# Altium schematic sheet Frost5000Beta_Sch.SchDoc(Frost5000Beta_Sch)
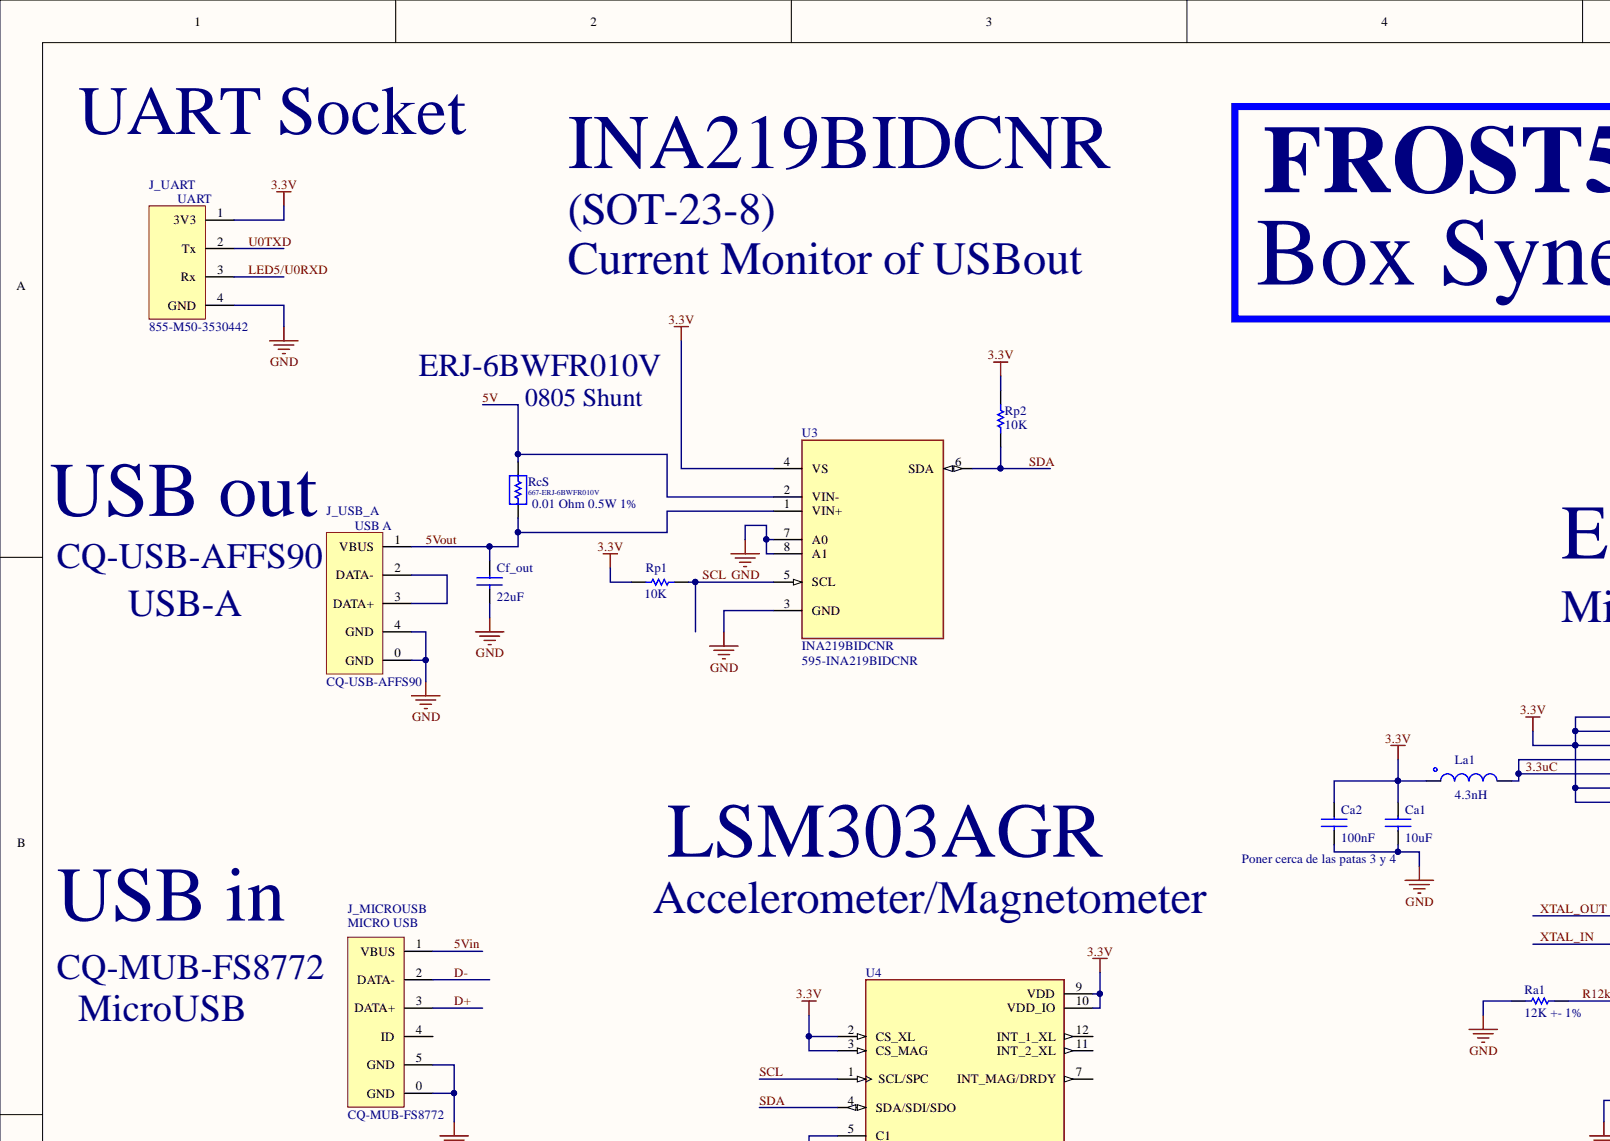
[diagram p0: Frost5000Beta_Sch.SchDoc, top left]
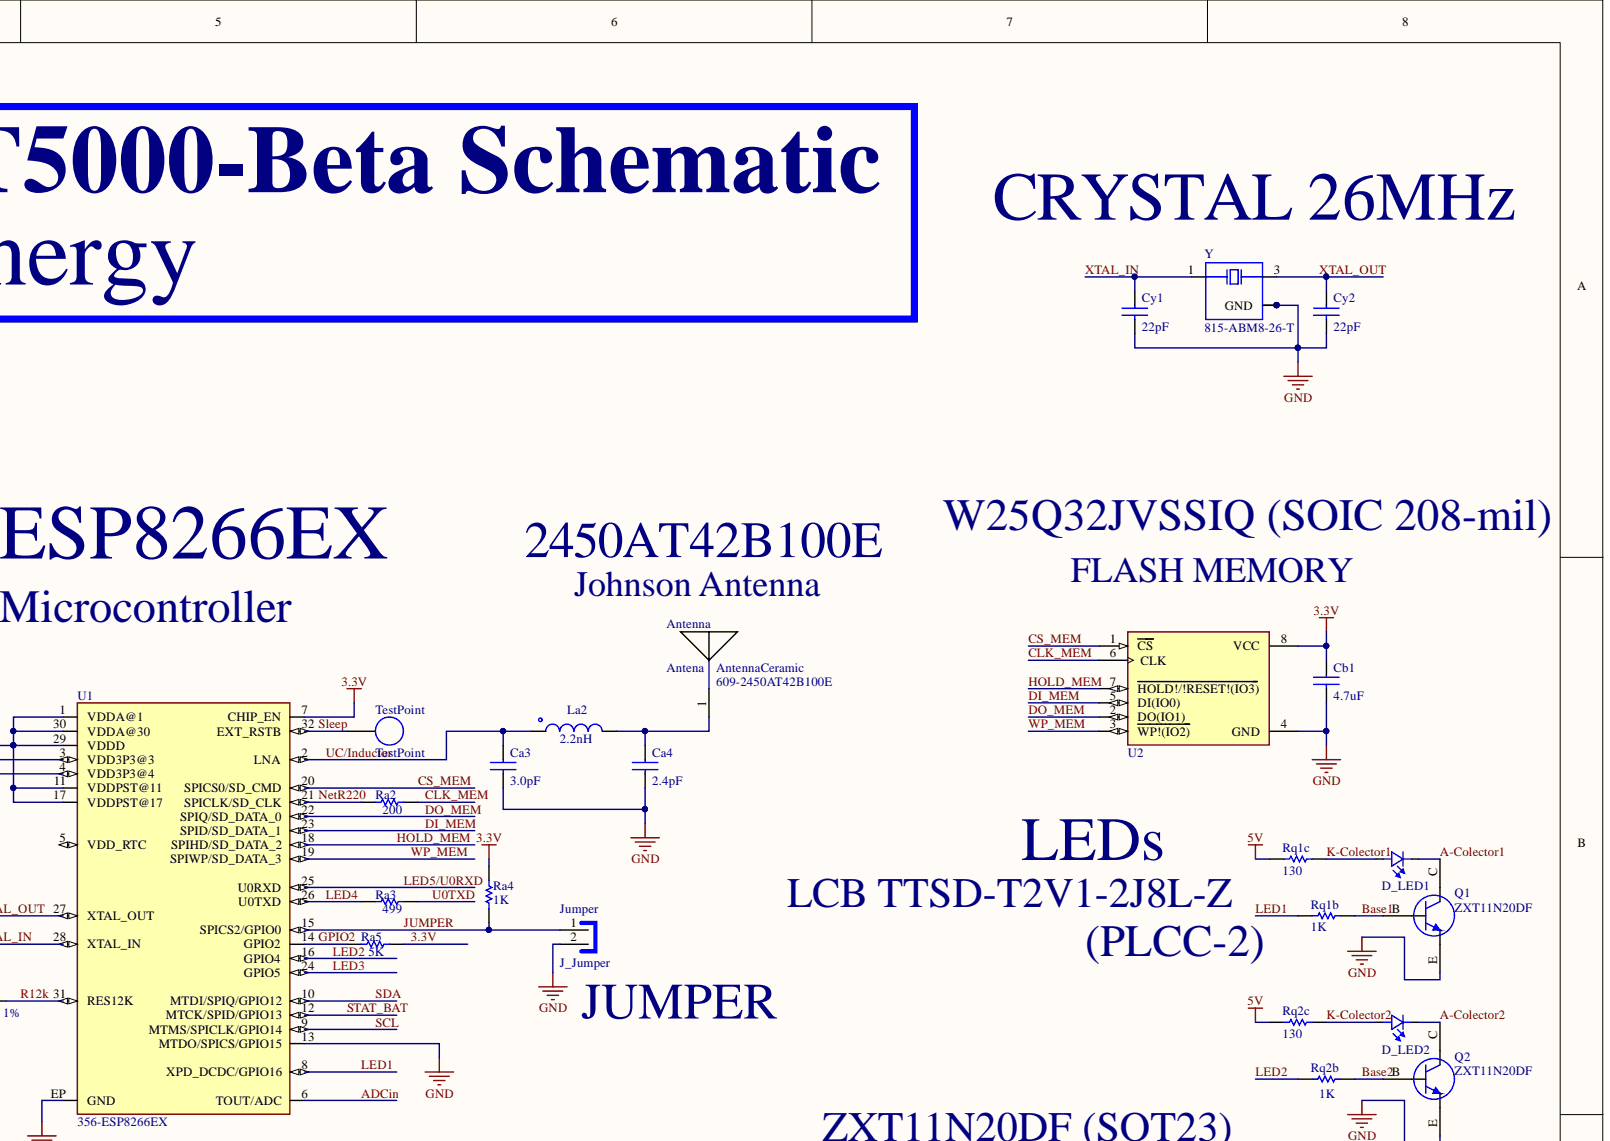
[diagram p1: Frost5000Beta_Sch.SchDoc, top right]
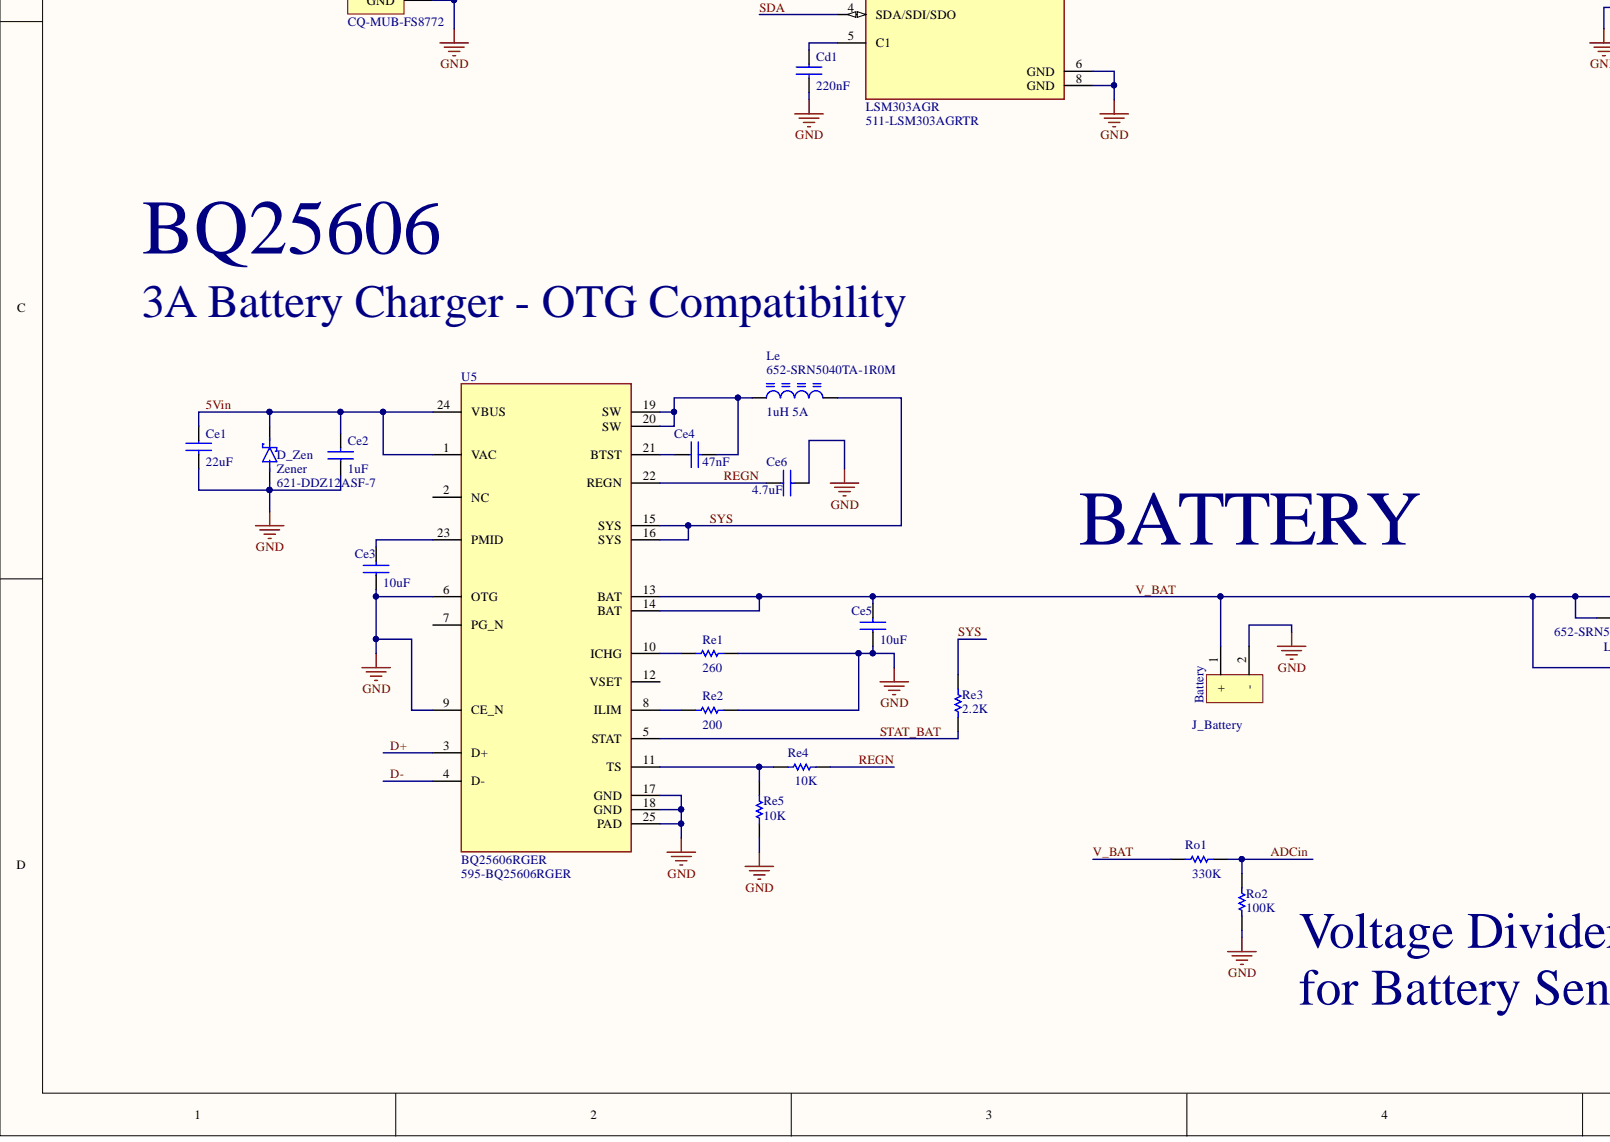
[diagram p2: Frost5000Beta_Sch.SchDoc, bottom left]
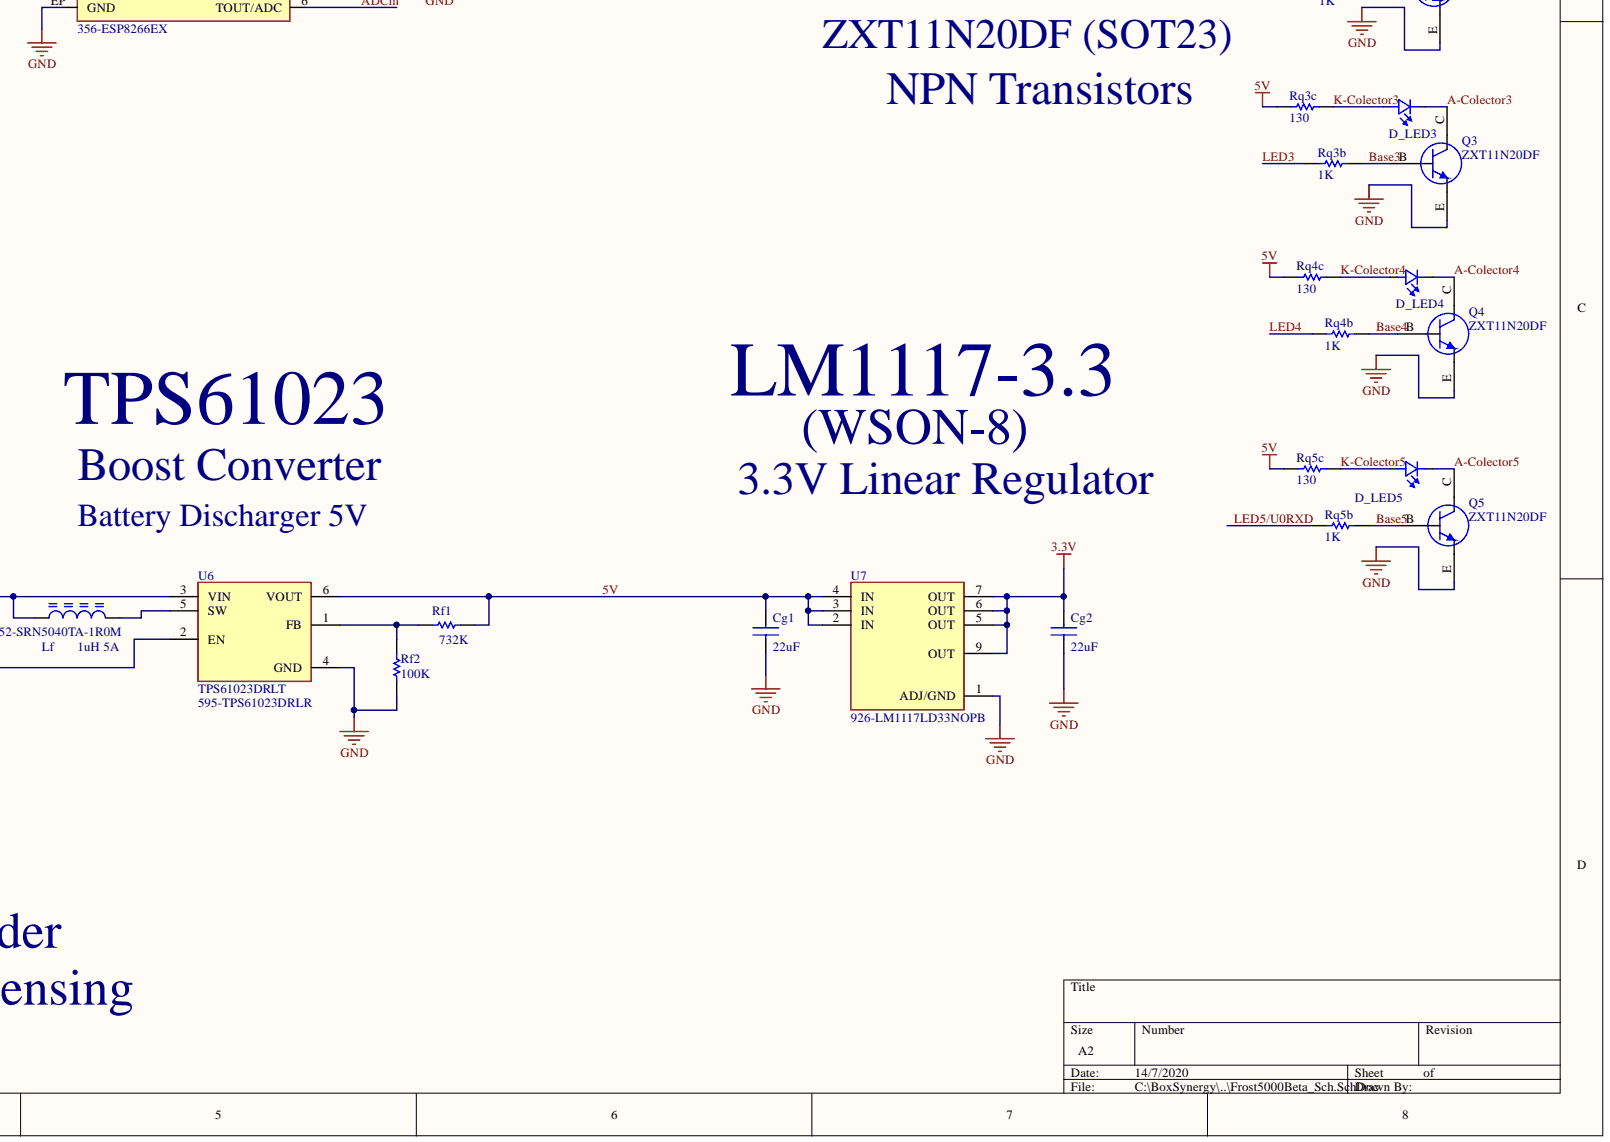
[diagram p3: Frost5000Beta_Sch.SchDoc, bottom right]

## Parts
Antenna  AntennaCeramic  Supplier Part Number 1: 609-2450AT42B100E  [ANTENNA_CERAMIC]
Ca1  10uF  [CAP_0603]
Ca2  100nF  [CAP_0603]
Ca3  3.0pF  [CAP_0402]
Ca4  2.4pF  [CAP_0402]
Cb1  4.7uF  [CAP_0603]
Cd1  220nF  [CAP_0603]
Ce1  22uF  [CAP_1210]
Ce2  1uF  [CAP_0603]
Ce3  10uF  [CAP_0603]
Ce4  47nF  [CAP_0603]
Ce5  10uF  [CAP_0603]
Ce6  4.7uF  [CAP_0603]
Cf_out  22uF  [CAP_1210]
Cg1  22uF  [CAP_0603]
Cg2  22uF  [CAP_0603]
Cy1  22pF  [CAP_0603]
Cy2  22pF  [CAP_0603]
D_LED1  LCB_TTSD  [LED_PLCC-2]  (value not drawn)
D_LED2  LCB_TTSD  [LED_PLCC-2]  (value not drawn)
D_LED3  LCB_TTSD  [LED_PLCC-2]  (value not drawn)
D_LED4  LCB_TTSD  [LED_PLCC-2]  (value not drawn)
D_LED5  LCB_TTSD  [LED_PLCC-2]  (value not drawn)
D_Zen  Zener  Supplier Part Number 1: 621-DDZ12ASF-7  [Zener-SOD323F]
J_Battery  Connx2_Battery  [Battery]  (value not drawn)
J_Jumper  Jumper  [JUMPER-1.27 mm]
J_MICROUSB  CQ-MUB-FS8772  [MICRO USB]
J_UART  Supplier Part Number 1: 855-M50-3530442  [UART-1.27mm]  (hidden comment: Connx4_UART)
J_USB_A  CQ-USB-AFFS90  [USB-A_IPX8]
La1  4.3nH  [IND_0603]
La2  2.2nH  [IND_0402]
Le  1uH 5A  Supplier Part Number 1: 652-SRN5040TA-1R0M  [Inductor_SRN5040]
Lf  1uH 5A  Supplier Part Number 1: 652-SRN5040TA-1R0M  [Inductor_SRN5040]
Q1  ZXT11N20DF  [Transistor_SOT23-3]
Q2  ZXT11N20DF  [Transistor_SOT23-3]
Q3  ZXT11N20DF  [Transistor_SOT23-3]
Q4  ZXT11N20DF  [Transistor_SOT23-3]
Q5  ZXT11N20DF  [Transistor_SOT23-3]
Ra1  12K +- 1%  [RES_0603]
Ra2  200  [RES_0603]
Ra3  499  [RES_0603]
Ra4  1K  [RES_0603]
Ra5  5K  [RES_0603]
RcS  0.01 Ohm 0.5W 1%  Supplier Part Number 1: 667-ERJ-6BWFR010V  [RES-SHUNT-0805]
Re1  260  [RES_0603]
Re2  200  [RES_0603]
Re3  2.2K  [RES_0603]
Re4  10K  [RES_0603]
Re5  10K  [RES_0603]
Rf1  732K  [RES_0603]
Rf2  100K  [RES_0603]
Ro1  330K  [RES_0603]
Ro2  100K  [RES_0603]
Rp1  10K  [RES_0603]
Rp2  10K  [RES_0603]
Rq1b  1K  [RES_0603]
Rq1c  130  [RES_0603]
Rq2b  1K  [RES_0603]
Rq2c  130  [RES_0603]
Rq3b  1K  [RES_0603]
Rq3c  130  [RES_0603]
Rq4b  1K  [RES_0603]
Rq4c  130  [RES_0603]
Rq5b  1K  [RES_0603]
Rq5c  130  [RES_0603]
TestPoint  TestPoint  [TestPoint]  (no BOM)
U1  ESP8266EX  Supplier Part Number 1: 356-ESP8266EX  [ESP8266_QFN32-5X5MM]
U2  W25Q32JVSSIQ  [W25Q32JVSSIQ_SOIC127P790X216-8N]
U3  INA219BIDCNR  Supplier Part Number 1: 595-INA219BIDCNR  [INA219B SOT23]
U4  LSM303AGR  Supplier Part Number 1: 511-LSM303AGRTR  [LSM303AGR-LGA12]
U5  BQ25606RGER  Supplier Part Number 1: 595-BQ25606RGER  [BQ25606]
U6  TPS61023DRLT  Supplier Part Number 1: 595-TPS61023DRLR  [TPS61023-SOT563]
U7  LM1117LD-3.3/NOPB  Supplier Part Number 1: 926-LM1117LD33NOPB  [LM1117-3.3-WSON-8]
Y  Supplier Part Number 1: 815-ABM8-26-T  [ABM8-26_OSCSC320X250X100N]  (hidden comment: ABM8-26MHz)

## Nets
GND: Ca1.2 Ca2.2 Ca3.2 Ca4.2 Cb1.2 Cd1.2 Ce1.2 Ce2.1 Ce3.1 Ce5.1 Ce6.2 Cf_out.2 Cg1.2 Cg2.2 Cy1.2 Cy2.2 D_Zen.A J_Battery.2 J_Jumper.2 J_MICROUSB.0(GND) J_MICROUSB.5(GND) J_UART.4(GND) J_USB_A.0(GND) J_USB_A.4(GND) Q1.E Q2.E Q3.E Q4.E Q5.E Ra1.2 Re1.2 Re2.2 Re5.1 Rf2.1 Ro2.1 U1.13(MTDO/SPICS/GPIO15) U1.EP(GND) U2.4(GND) U3.3(GND) U3.7(A0) U3.8(A1) U4.6(GND) U4.8(GND) U5.6(OTG) U5.9(CE_N) U5.17(GND) U5.18(GND) U5.25(PAD) U6.4(GND) U7.1(ADJ/GND) Y.2(GND) Y.4
3.3uC: La1.2 U1.3(VDD3P3@3) U1.4(VDD3P3@4)
3.3V: Ca1.1 Ca2.1 Cb1.1 Cg2.1 J_UART.1(3V3) La1.1 Ra4.2 Ra5.2 Rp1.1 Rp2.1 U1.1(VDDA@1) U1.7(CHIP_EN) U1.11(VDDPST@11) U1.17(VDDPST@17) U1.29(VDDD) U1.30(VDDA@30) U2.8(VCC) U3.4(VS) U4.2(CS_XL) U4.3(CS_MAG) U4.9(VDD) U4.10(VDD_IO) U7.5(OUT) U7.6(OUT) U7.7(OUT) U7.9(OUT)
5V: Cg1.1 RcS.2 Rf1.2 Rq1c.2 Rq2c.2 Rq3c.2 Rq4c.2 Rq5c.2 U3.2(VIN-) U6.6(VOUT) U7.2(IN) U7.3(IN) U7.4(IN)
5Vin: Ce1.1 Ce2.2 D_Zen.C J_MICROUSB.1(VBUS) U5.1(VAC) U5.24(VBUS)
5Vout: Cf_out.1 J_USB_A.1(VBUS) RcS.1 U3.1(VIN+)
A-Colector1: D_LED1.1 Q1.C
A-Colector2: D_LED2.1 Q2.C
A-Colector3: D_LED3.1 Q3.C
A-Colector4: D_LED4.1 Q4.C
A-Colector5: D_LED5.1 Q5.C
ADCin: Ro1.2 Ro2.2 U1.6(TOUT/ADC)
Base1: Q1.B Rq1b.1
Base2: Q2.B Rq2b.1
Base3: Q3.B Rq3b.1
Base4: Q4.B Rq4b.1
Base5: Q5.B Rq5b.1
CLK_MEM: Ra2.2 U2.6(CLK)
CS_MEM: U1.20(SPICS0/SD_CMD) U2.1(CS)
D+: J_MICROUSB.3(DATA+) U5.3(D+)
D-: J_MICROUSB.2(DATA-) U5.4(D-)
DI_MEM: U1.23(SPID/SD_DATA_1) U2.5(DI(IO0))
DO_MEM: U1.22(SPIQ/SD_DATA_0) U2.2(DO(IO1))
GPIO2: Ra5.1 U1.14(GPIO2)
HOLD_MEM: U1.18(SPIHD/SD_DATA_2) U2.7(HOLD!/!RESET!(IO3))
JUMPER: J_Jumper.1 Ra4.1 U1.15(SPICS2/GPIO0)
K-Colector1: D_LED1.2 Rq1c.1
K-Colector2: D_LED2.2 Rq2c.1
K-Colector3: D_LED3.2 Rq3c.1
K-Colector4: D_LED4.2 Rq4c.1
K-Colector5: D_LED5.2 Rq5c.1
LED1: Rq1b.2 U1.8(XPD_DCDC/GPIO16)
LED2: Rq2b.2 U1.16(GPIO4)
LED3: Rq3b.2 U1.24(GPIO5)
LED4: Ra3.1 Rq4b.2 U1.26(U0TXD)
LED5/U0RXD: J_UART.3(Rx) Rq5b.2 U1.25(U0RXD)
NetR220: Ra2.1 U1.21(SPICLK/SD_CLK)
R12k: Ra1.1 U1.31(RES12K)
REGN: Ce6.1 Re4.2 U5.22(REGN)
SCL: Rp1.2 U1.9(MTMS/SPICLK/GPIO14) U3.5(SCL) U4.1(SCL/SPC)
SDA: Rp2.2 U1.10(MTDI/SPIQ/GPIO12) U3.6(SDA) U4.4(SDA/SDI/SDO)
Sleep: TestPoint.1 U1.32(EXT_RSTB)
STAT_BAT: Re3.1 U1.12(MTCK/SPID/GPIO13) U5.5(STAT)
SYS: Le.1 Re3.2 U5.15(SYS) U5.16(SYS)
U0TXD: J_UART.2(Tx) Ra3.2
UC/Inductor: Ca3.1 La2.1 U1.2(LNA)
V_BAT: Ce5.2 J_Battery.1 Lf.2 Ro1.1 U5.13(BAT) U5.14(BAT) U6.2(EN) U6.3(VIN)
WP_MEM: U1.19(SPIWP/SD_DATA_3) U2.3(WP!(IO2))
XTAL_IN: Cy1.1 U1.28(XTAL_IN) Y.1
XTAL_OUT: Cy2.1 U1.27(XTAL_OUT) Y.3
NetAntenna_1: Antenna.1 Ca4.1 La2.2
NetCd1_1: Cd1.1 U4.5(C1)
NetCe3_2: Ce3.2 U5.23(PMID)
NetCe4_1: Ce4.1 U5.21(BTST)
NetCe4_2: Ce4.2 Le.2 U5.19(SW) U5.20(SW)
NetJ_USB_A_2: J_USB_A.2(DATA-) J_USB_A.3(DATA+)
NetLf_1: Lf.1 U6.5(SW)
NetRe1_1: Re1.1 U5.10(ICHG)
NetRe2_1: Re2.1 U5.8(ILIM)
NetRe4_1: Re4.1 Re5.2 U5.11(TS)
NetRf1_1: Rf1.1 Rf2.2 U6.1(FB)

Not connected: J_MICROUSB 4(ID); U1 5(VDD_RTC); U4 7(INT_MAG/DRDY) 11(INT_2_XL) 12(INT_1_XL); U5 2(NC) 7(PG_N) 12(VSET)

## Library data of the parts
Antenna = AntennaCeramic: Antena de Cerámica; Supplier 1: Mouser; Component Kind: Standard; Library Name: Frost5000Beta_LIB_Otros_Sch.SchLib
Ca1 Ca2 Cb1 Cd1 Cg1 Cg2 Cy1 Cy2 = Cap_0603_Ceramic: Capac Ceramic SMD; Component Kind: Standard; Library Name: Frost5000Beta_LIB_Pasivos_Sch.SchLib
Ca3 Ca4 = Cap_0402: Capac Ceramic SMD; Component Kind: Standard; Library Name: Frost5000Beta_LIB_Pasivos_Sch.SchLib
Ce1 = Cap_1210_Ceramic: Capac Cin1; Component Kind: Standard; Library Name: Frost5000Beta_LIB_Pasivos_Sch.SchLib
Ce2 = Cap_0603_Ceramic: Capac Cin2; Component Kind: Standard; Library Name: Frost5000Beta_LIB_Pasivos_Sch.SchLib
Ce3 = Cap_0603_Ceramic: Capacitor PMID; Component Kind: Standard; Library Name: Frost5000Beta_LIB_Pasivos_Sch.SchLib
Ce4 Ce6 = Cap_0603_Ceramic: Capacitor Bootstrap 47nF; Component Kind: Standard; Library Name: Frost5000Beta_LIB_Pasivos_Sch.SchLib
Ce5 = Cap_0603_Ceramic: Capac Cout; Component Kind: Standard; Library Name: Frost5000Beta_LIB_Pasivos_Sch.SchLib
Cf_out = Cap_1210_Ceramic: Capac Ceramic SMD; Component Kind: Standard; Library Name: Frost5000Beta_LIB_Pasivos_Sch.SchLib
D_LED1-D_LED5 = LCB_TTSD: LED PLCC-2; MP: HSMW-C191; MF: Broadcom Limited; SnapEDA_Link: https://www.snapeda.com/parts/HSMW-C191/Broadcom%20Limited/view-part/1840124/?ref=snap; Price: None; Package: 0603 Broadcom; Component Kind: Standard; Availability: Unavailable; Library Name: Frost5000Beta_LIB_Otros_Sch.SchLib
D_Zen = Zener: Zener 11.5V / 0.5W; MP: SMF5.0AT1G; MF: Littelfuse; Supplier 1: Mouser; SnapEDA_Link: https://www.snapeda.com/parts/SMF5.0AT1G/Littelfuse/view-part/4502608/?ref=snap; Price: None; Package: SOD-123 Littelfuse; Component Kind: Standard; Availability: Unavailable; Library Name: Frost5000Beta_LIB_Otros_Sch.SchLib
J_Battery = Connx2_Battery: 2.54mm Pin Header 2x1; Component Kind: Standard; Library Name: Frost5000Beta_LIB_Conectores_Sch.SchLib
J_Jumper = Jumper: 2.54mm Pin Header 2x1; Supplier Part Number 1: 855-M20-9990245; Supplier 1: Mouser; Component Kind: Standard; Library Name: Frost5000Beta_LIB_Conectores_Sch.SchLib
J_MICROUSB = MicroUSB: Conector MicroUSB   --   CQ-MUB-FS8772; Component Kind: Standard; Library Name: Frost5000Beta_LIB_Conectores_Sch.SchLib
J_UART = Connx4_UART: 1.27mm Pin Header 4x1; Supplier 1: Mouser; Component Kind: Standard; Library Name: Frost5000Beta_LIB_Conectores_Sch.SchLib
J_USB_A = USB-A 5V: Conector del USB   --   CQ-USB-AFFS90; Component Kind: Standard; Library Name: Frost5000Beta_LIB_Conectores_Sch.SchLib
La1 = Ind_0603: Inductor SMD 0603; MP: LQP03TN62NHZ2D; MF: Murata; SnapEDA_Link: https://www.snapeda.com/parts/LQP03TN62NHZ2D/Murata/view-part/4472751/?ref=snap; Price: None; Package: 0603 Murata; Component Kind: Standard; Availability: Unavailable; Library Name: Frost5000Beta_LIB_Pasivos_Sch.SchLib
La2 = Ind_0402: Inductor SMD 0402; MP: LQP03TN62NHZ2D; MF: Murata; SnapEDA_Link: https://www.snapeda.com/parts/LQP03TN62NHZ2D/Murata/view-part/4472751/?ref=snap; Price: None; Package: 0603 Murata; Component Kind: Standard; Availability: Unavailable; Library Name: Frost5000Beta_LIB_Pasivos_Sch.SchLib
Le Lf = Ind_SRN5040: Inductor_SRN5040; MF: Vishay Dale; Supplier 1: Mouser; SnapEDA_Link: https://www.snapeda.com/parts/IHLP-2525CZ-01/Vishay%20Dale/view-part/561997/?ref=snap; Price: None; Package: Nonstandard Vishay Dale; Component Kind: Standard; Availability: Unavailable; Library Name: Frost5000Beta_LIB_Pasivos_Sch.SchLib
Q1-Q5 = ZXT11N20DF: Transistor NPN Low Saturation Vce - SOT23-3; Supplier Part Number 1: 522-ZXT11N20DFTA; Supplier 1: Mouser; Component Kind: Standard; Library Name: Frost5000Beta_LIB_Integrados_Sch.SchLib
Ra1-Ra5 Rf1 Rf2 Ro1 Ro2 Rp1 Rp2 Rq1b Rq1c Rq2b Rq2c Rq3b Rq3c Rq4b Rq4c Rq5b Rq5c = Res_0603: Resistor SMD; Simulation: RESISTOR; Signal Integrity: Res; Published: 8-Jun-2000; PackageReference: AXIAL-0.3; PackageDescription: Axial Device, Thru-Hole; 2 Leads; 0.3 in Pin Spacing; LatestRevisionNote: Re-released for DXP Platform.; LatestRevisionDate: 17-Jul-2002; Component Kind: Standard; Publisher: Altium Limited; Library Name: Frost5000Beta_LIB_Pasivos_Sch.SchLib
RcS = Shunt_0805: Shunt; Supplier 1: Mouser; Simulation: RESISTOR; Signal Integrity: Res; Published: 8-Jun-2000; PackageReference: AXIAL-0.3; PackageDescription: Axial Device, Thru-Hole; 2 Leads; 0.3 in Pin Spacing; LatestRevisionNote: Re-released for DXP Platform.; LatestRevisionDate: 17-Jul-2002; Component Kind: Standard; Publisher: Altium Limited; Library Name: Frost5000Beta_LIB_Pasivos_Sch.SchLib
Re1 = Res_0603: Resistor ICHG; Simulation: RESISTOR; Signal Integrity: Res; Published: 8-Jun-2000; PackageReference: AXIAL-0.3; PackageDescription: Axial Device, Thru-Hole; 2 Leads; 0.3 in Pin Spacing; LatestRevisionNote: Re-released for DXP Platform.; LatestRevisionDate: 17-Jul-2002; Component Kind: Standard; Publisher: Altium Limited; Library Name: Frost5000Beta_LIB_Pasivos_Sch.SchLib
Re2 = Res_0603: Resistor ILIM; Simulation: RESISTOR; Signal Integrity: Res; Published: 8-Jun-2000; PackageReference: AXIAL-0.3; PackageDescription: Axial Device, Thru-Hole; 2 Leads; 0.3 in Pin Spacing; LatestRevisionNote: Re-released for DXP Platform.; LatestRevisionDate: 17-Jul-2002; Component Kind: Standard; Publisher: Altium Limited; Library Name: Frost5000Beta_LIB_Pasivos_Sch.SchLib
Re3 = Res_0603: Resistor BAT Status; Simulation: RESISTOR; Signal Integrity: Res; Published: 8-Jun-2000; PackageReference: AXIAL-0.3; PackageDescription: Axial Device, Thru-Hole; 2 Leads; 0.3 in Pin Spacing; LatestRevisionNote: Re-released for DXP Platform.; LatestRevisionDate: 17-Jul-2002; Component Kind: Standard; Publisher: Altium Limited; Library Name: Frost5000Beta_LIB_Pasivos_Sch.SchLib
Re4 = Res_0603: Res Temperat 1; Simulation: RESISTOR; Signal Integrity: Res; Published: 8-Jun-2000; PackageReference: AXIAL-0.3; PackageDescription: Axial Device, Thru-Hole; 2 Leads; 0.3 in Pin Spacing; LatestRevisionNote: Re-released for DXP Platform.; LatestRevisionDate: 17-Jul-2002; Component Kind: Standard; Publisher: Altium Limited; Library Name: Frost5000Beta_LIB_Pasivos_Sch.SchLib
Re5 = Res_0603: Res Temperat 2; Simulation: RESISTOR; Signal Integrity: Res; Published: 8-Jun-2000; PackageReference: AXIAL-0.3; PackageDescription: Axial Device, Thru-Hole; 2 Leads; 0.3 in Pin Spacing; LatestRevisionNote: Re-released for DXP Platform.; LatestRevisionDate: 17-Jul-2002; Component Kind: Standard; Publisher: Altium Limited; Library Name: Frost5000Beta_LIB_Pasivos_Sch.SchLib
U1 = ESP8266EX: Microcontroller ESP8266EX; MF: Espressif Systems; Supplier 1: Mouser; SnapEDA_Link: https://www.snapeda.com/parts/ESP8266/Espressif%20Systems/view-part/106411/?ref=snap; Price: None; Package: VFQFN-32 Espressif Systems; MP: ESP8266; Component Kind: Standard; Availability: Unavailable; Library Name: Summits7Beta_LIB_Integrados_Sch.SchLib
U2 = W25Q32JVSSIQ: FLASH - NOR Memory IC 32Mb _4M x 8_ SPI - Quad I/O 133MHz 8-SOIC; MF: Winbond; SnapEDA_Link: https://www.snapeda.com/parts/W25Q32JVSSIQ/Winbond%20Electronics/view-part/596953/?ref=snap; Price: None; Package: SOIC-8 Winbond; MP: W25Q32JVSSIQ; Component Kind: Standard; Availability: Unavailable; Library Name: Frost5000Beta_LIB_Integrados_Sch.SchLib
U3 = INA219BIDCNR: Current Monitor Regulator SOT-23-8; MF: Texas Instruments; Supplier 1: Mouser; SnapEDA_Link: https://www.snapeda.com/parts/INA219BIDCNR/Texas%20Instruments/view-part/870494/?ref=snap; Package: SOT-23 Texas Instruments; MP: INA219BIDCNR; Digi-Key_Purchase_URL: https://www.digikey.com.au/product-detail/en/texas-instruments/INA219BIDCNR/296-46420-1-ND/7033594?utm_source=snapeda&utm_medium=aggregator&utm_campaign=symbol; Digi-Key_Part_Number: 296-46420-1-ND; Component Kind: Standard; Library Name: Frost5000Beta_LIB_Integrados_Sch.SchLib
U4 = LSM303AGR: Accelerometer, Magnetometer - LGA12; MF: STMicroelectronics; Supplier 1: Mouser; SnapEDA_Link: https://www.snapeda.com/parts/LSM303AGR/STMicroelectronics/view-part/3601838/?ref=snap; Price: None; Package: VFLGA-12 STMicroelectronics; MP: LSM303AGR; Component Kind: Standard; Availability: Unavailable; Library Name: Frost5000Beta_LIB_Integrados_Sch.SchLib
U5 = BQ25606RGER: Battery Charger 3A - BQ25606; Manufacturer: Texas Instruments; Value: Value; Type: DEV; Supplier 1: Mouser; RefDes: RefDes; PartNumber: BQ25606RGER; Component Kind: Standard; Library Name: Frost5000Beta_LIB_Integrados_Sch.SchLib; Copyright: Copyright (C) 2018 Accelerated Designs. All rights reserved
U6 = TPS61023DRLT: Boost Switching Regulator IC Positive Adjustable 2.2V 1 Output 3A SOT-563, SOT-666; MF: Texas Instruments; Supplier 1: Mouser; SnapEDA_Link: https://www.snapeda.com/parts/TPS61023DRLT/Texas%20Instruments/view-part/4477974/?ref=snap; Price: None; Package: SOT-563 Texas Instruments; MP: TPS61023DRLT; Component Kind: Standard; Availability: Unavailable; Library Name: Frost5000Beta_LIB_Integrados_Sch.SchLib
U7 = LM1117LD-3.3/NOPB: LDO Regulator Pos 3.3V 0.8A Texas - WSON8; MP: LT1117IST-5#PBF; MF: Analog Devices; Supplier 1: Mouser; SnapEDA_Link: https://www.snapeda.com/parts/LT1117IST-5%23PBF/Analog%20Devices/view-part/4348177/?ref=snap; Price: None; Package: SOT-223-3 Analog Devices; Component Kind: Standard; Availability: Unavailable; Library Name: Frost5000Beta_LIB_Integrados_Sch.SchLib
Y = ABM8-26MHz: 26MHz Â±10ppm Crystal 10pF 50 Ohms 4-SMD, No Lead; MP: ABM8-26.000MHZ-10-1-U-T; MF: Abracon; Supplier 1: Mouser; SnapEDA_Link: https://www.snapeda.com/parts/ABM8-26.000MHZ-10-1-U-T/Abracon%20LLC/view-part/356267/?ref=snap; Package: SMD-4 Abracon; Digi-Key_Purchase_URL: https://www.digikey.com/product-detail/en/abracon-llc/ABM8-26.000MHZ-10-1-U-T/300-8230-1-ND/687923?utm_source=snapeda&utm_medium=aggregator&utm_campaign=symbol; Digi-Key_Part_Number: 300-8230-1-ND; Component Kind: Standard; Library Name: Frost5000Beta_LIB_Otros_Sch.SchLib
TestPoint = TestPoint: Library Name: Frost5000Beta_LIB_Otros_Sch.SchLib
